# Altium schematic sheet Sheet3.SchDoc(Sheet3)
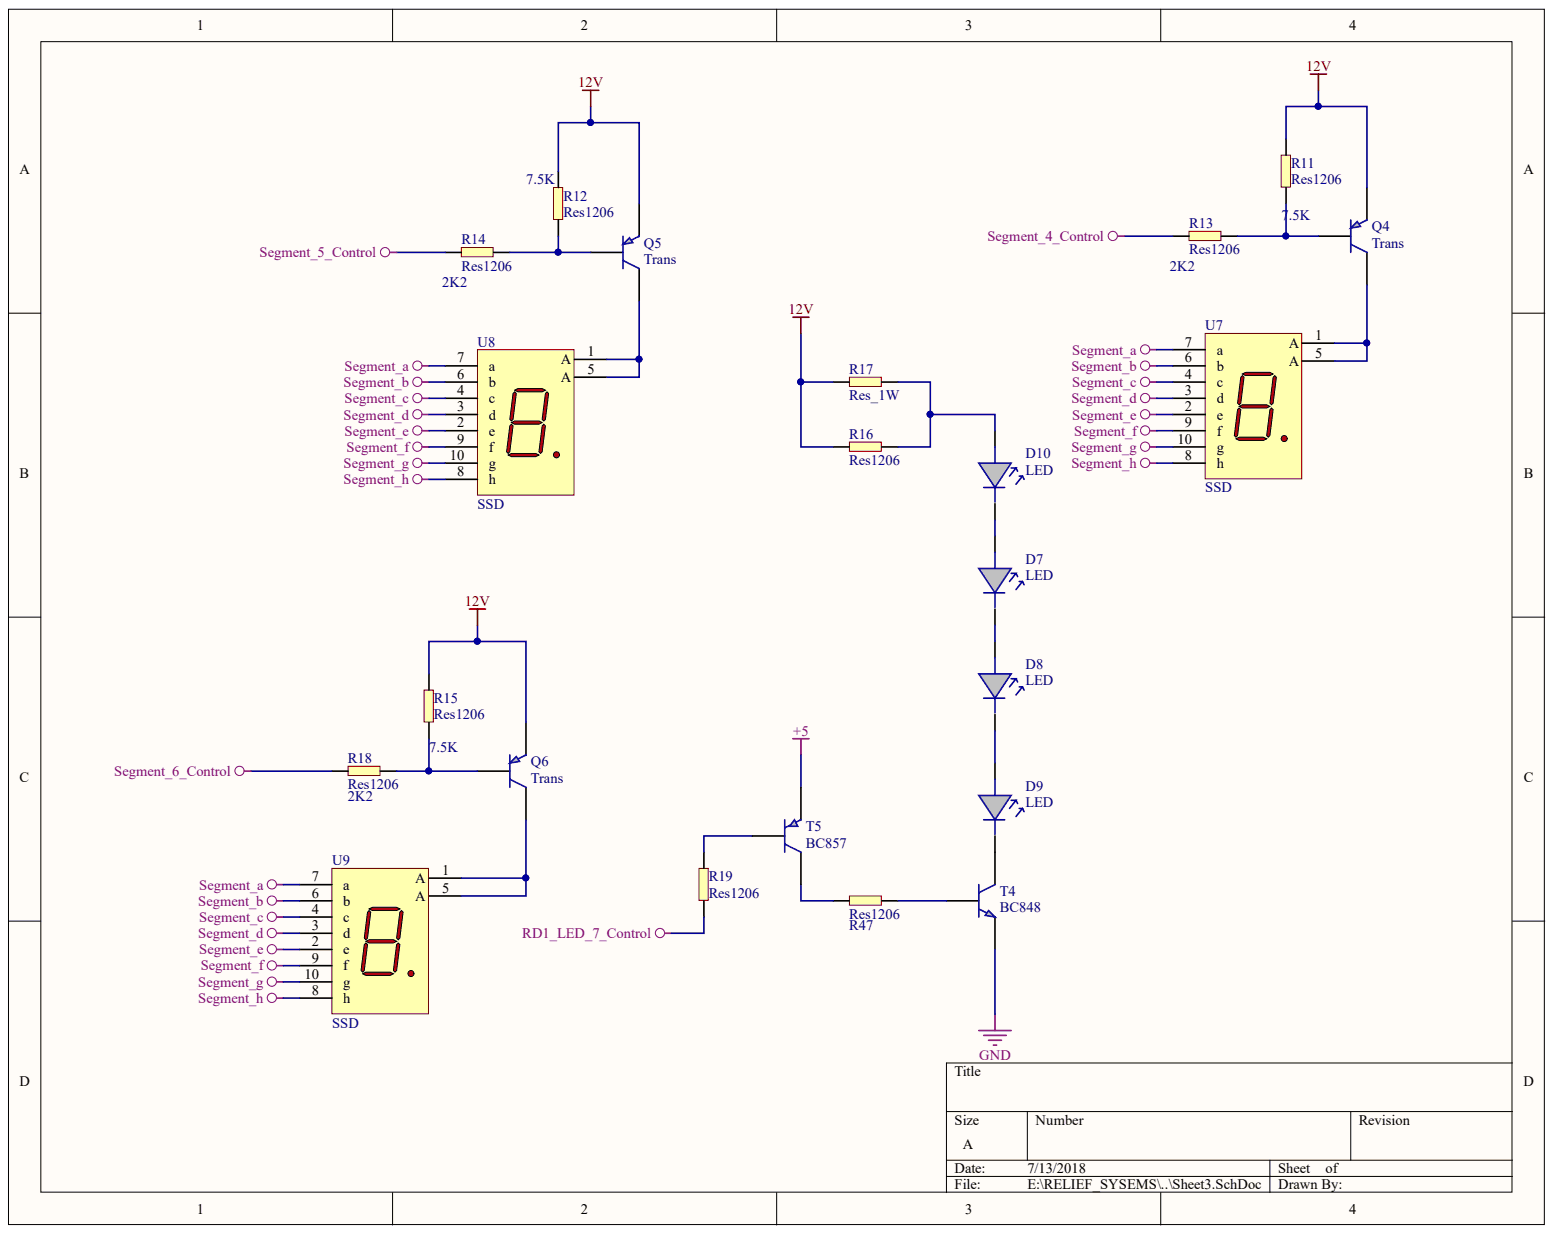
[diagram p0: Sheet3.SchDoc]

## Parts
D7  LED  [LED5MM]
D8  LED  [LED5MM]
D9  LED  [LED5MM]
D10  LED  [LED5MM]
Q4  Trans  [2N6107]
Q5  Trans  [2N6107]
Q6  Trans  [2N6107]
R11  Res1206  value 7.5K  [1206]
R12  Res1206  value 7.5K  [1206]
R13  Res1206  value 2K2  [1206]
R14  Res1206  value 2K2  [1206]
R15  Res1206  value 7.5K  [1206]
R16  Res1206  [1206]
R17  Res_1W  [RES_400_1W]
R18  Res1206  value 2K2  [1206]
R19  Res1206  [1206]
R47  Res1206  [1206]
T4  BC848  [SOT23]
T5  BC857  [SOT23]
U7  SSD  [SP1802_SSD]
U8  SSD  [SP1802_SSD]
U9  SSD  [SP1802_SSD]

## Nets
12V: Q4.3 Q5.3 Q6.3 R11.2 R12.1 R15.2 R16.1 R17.2
+5: T5.2
GND: T4.2
RD1_LED_7_CONTROL: R19.2
SEGMENT_4_CONTROL: R13.1
SEGMENT_5_CONTROL: R14.2
SEGMENT_6_CONTROL: R18.1
SEGMENT_A: U7.7(a) U8.7(a) U9.7(a)
SEGMENT_B: U7.6(b) U8.6(b) U9.6(b)
SEGMENT_C: U7.4(c) U8.4(c) U9.4(c)
SEGMENT_D: U7.3(d) U8.3(d) U9.3(d)
SEGMENT_E: U7.2(e) U8.2(e) U9.2(e)
SEGMENT_F: U7.9(f) U8.9(f) U9.9(f)
SEGMENT_G: U7.10(g) U8.10(g) U9.10(g)
SEGMENT_H: U7.8(h) U8.8(h) U9.8(h)
NetD7_1: D7.1 D10.2
NetD7_2: D7.2 D8.1
NetD8_2: D8.2 D9.1
NetD9_2: D9.2 T4.3
NetD10_1: D10.1 R16.2 R17.1
NetQ4_1: Q4.1 R11.1 R13.2
NetQ4_2: Q4.2 U7.1(A) U7.5(A)
NetQ5_1: Q5.1 R12.2 R14.1
NetQ5_2: Q5.2 U8.1(A) U8.5(A)
NetQ6_1: Q6.1 R15.1 R18.2
NetQ6_2: Q6.2 U9.1(A) U9.5(A)
NetR19_1: R19.1 T5.1
NetR47_1: R47.1 T4.1
NetR47_2: R47.2 T5.3

## Library data of the parts
D7-D10 = LED_5MM: Component Kind: Standard; Description: LED_5MM; Library Name: Dinesh_New_Integrated_Library.IntLib
Q4-Q6 = 2N6107: pnp transistor; Component Kind: Standard; Library Name: VIJAYIntegrated_Library.IntLib
T4 = BC848: TRANSISTOR; Component Kind: Standard; Library Name: Dinesh_New_Integrated_Library.IntLib
U7-U9 = SP1802: SSD 48MM; Component Kind: Standard; Library Name: VIJAYIntegrated_Library.IntLib
R11-R16 R18 R19 R47 = Res1206: Component Kind: Standard; Description: Res1206; Library Name: Dinesh_New_Integrated_Library.IntLib
R17 = Res_1W: Component Kind: Standard; Description: Res_1W; Library Name: Dinesh_New_Integrated_Library.IntLib
T5 = BC857: Component Kind: Standard; Description: BC857; Library Name: Dinesh_New_Integrated_Library.IntLib
